# Altium schematic sheet IoTsmart RP2350A 2-02.SchDoc("IoTsmart RP2350A 2-02")
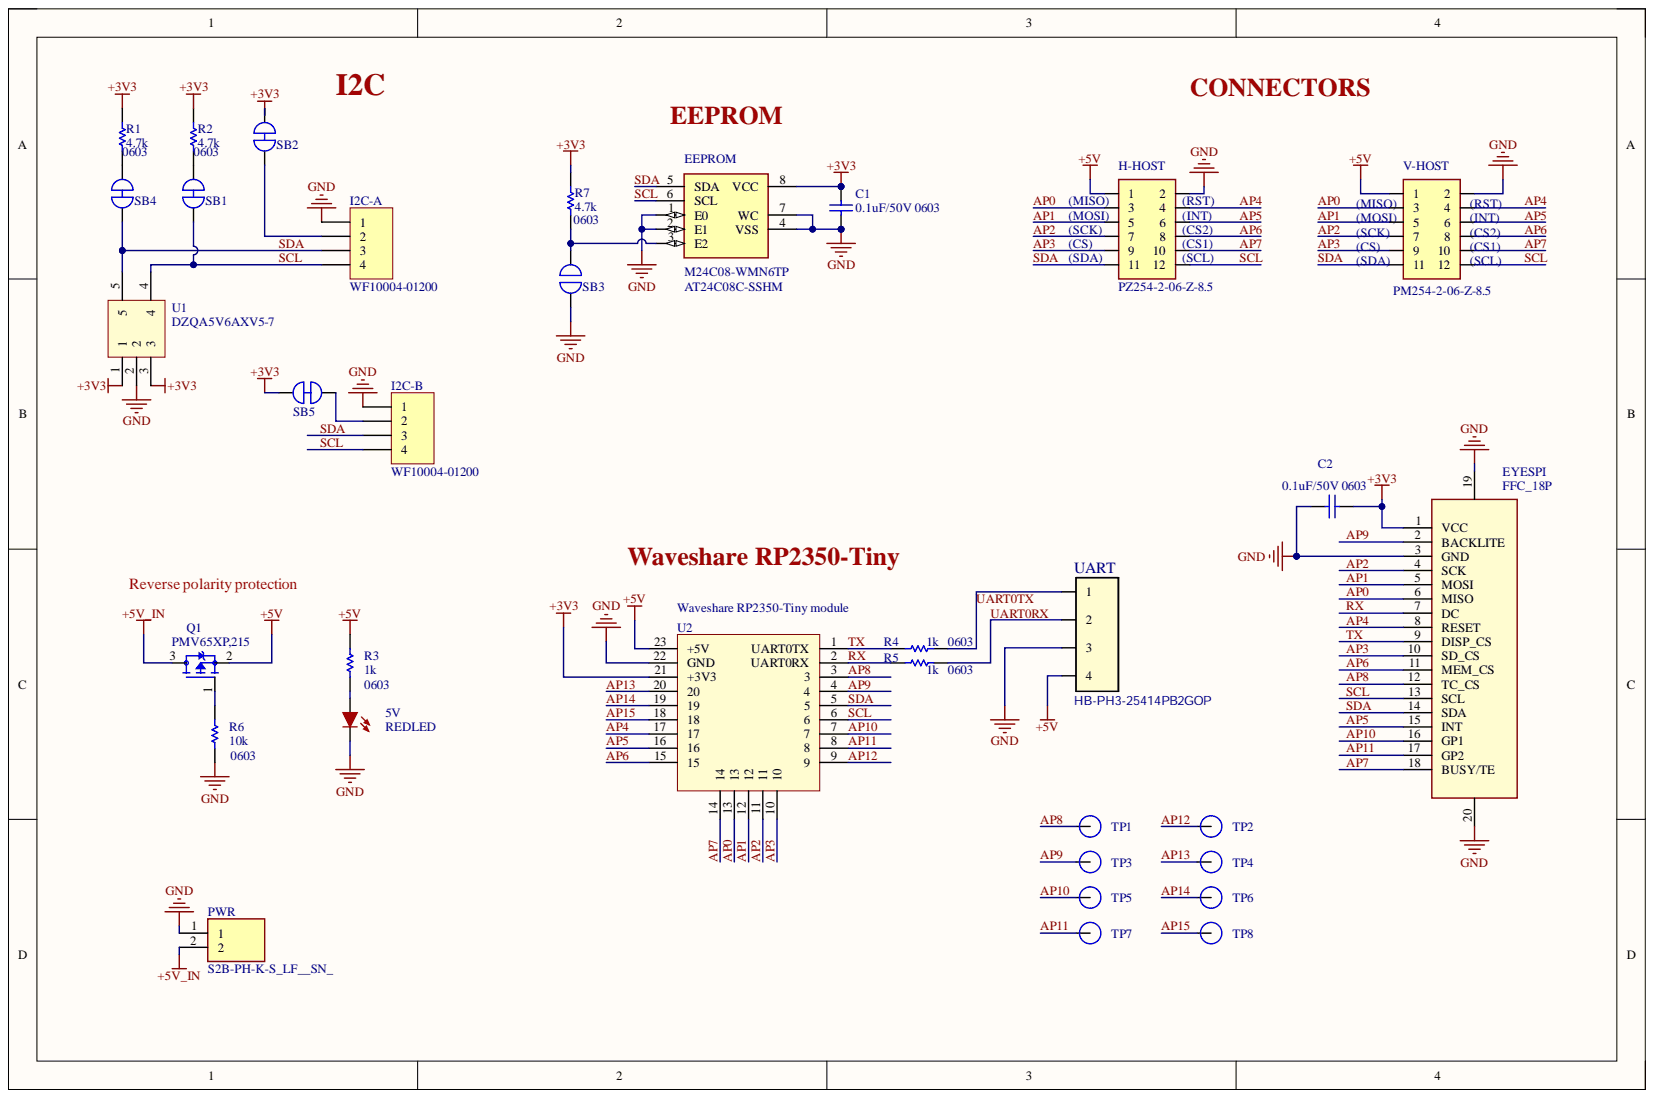
[diagram p0: IoTsmart RP2350A 2-02.SchDoc]

## Parts
5V  REDLED  Case Code (Imperial): 0603  Reverse Voltage: 5V  [LED0603_RED]
C1  0.1uF/50V 0603  [CAPC1608X100N]
C2  0.1uF/50V 0603  [CAPC1608X100N]
EEPROM  M24C08-WMN6TP  [SOIC-8]
EYESPI  FFC_18P  [FFC_18P]
H-HOST  PZ254-2-06-Z-8.5  [PBD-12R]
I2C-A  WF10004-01200  [SM04B-SRSS-TB(LF)(SN)]
I2C-B  WF10004-01200  [SM04B-SRSS-TB(LF)(SN)]
PWR  S2B-PH-K-S_LF__SN_  [SHDRRA2W50P0X200_1X2_590X760X480P]
Q1  PMV65XP,215  [SOT95P230X110-3N]
R1  4.7k  [RESC1608X50N]
R2  4.7k  [RESC1608X50N]
R3  1k  [RESC1608X50N]
R4  1k  [RESC1608X50N]
R5  1k  [RESC1608X50N]
R6  10k  [RESC1608X50N]
R7  4.7k  [RESC1608X50N]
SB1  SOLDER_JUMPER_NC  [SOLDER_JUMPER_NC_2]  (value not drawn)  (no BOM)
SB2  SOLDER_JUMPER_NC  [SOLDER_JUMPER_NC_2]  (value not drawn)  (no BOM)
SB3  SOLDER_JUMPER_NC  [SOLDER_JUMPER_NC_2-open]  (value not drawn)  (no BOM)
SB4  SOLDER_JUMPER_NC  [SOLDER_JUMPER_NC_2]  (value not drawn)  (no BOM)
SB5  SOLDER_JUMPER_NC  [SOLDER_JUMPER_NC_2]  (value not drawn)  (no BOM)
TP1  TP  [TEST_PAD]  (no BOM)
TP2  TP  [TEST_PAD]  (no BOM)
TP3  TP  [TEST_PAD]  (no BOM)
TP4  TP  [TEST_PAD]  (no BOM)
TP5  TP  [TEST_PAD]  (no BOM)
TP6  TP  [TEST_PAD]  (no BOM)
TP7  TP  [TEST_PAD]  (no BOM)
TP8  TP  [TEST_PAD]  (no BOM)
U1  DZQA5V6AXV5-7  [SOT50P160X60-5N]
U2  Waveshare RP2350-Tiny module  [ESP32S3Tiny]
UART  HB-PH3-25414PB2GOP  [XS PLS-4]
V-HOST  PM254-2-06-Z-8.5  [PBD-12]

## Nets
+3V3: C1.2 C2.1 EEPROM.8 EYESPI.1 R1.2 R2.2 R7.2 SB2.2 SB5.2 U1.1 U1.3 U2.21
+5V: H-HOST.1 Q1.2 R3.2 U2.23 UART.4 V-HOST.1
+5V_IN: PWR.2 Q1.3
GND: 5V.K C1.1 C2.2 EEPROM.1 EEPROM.2 EEPROM.4 EEPROM.7 EYESPI.3 EYESPI.19 EYESPI.20 H-HOST.2 I2C-A.1 I2C-B.1 PWR.1 R6.1 SB3.1 U1.2 U2.22 UART.3 V-HOST.2
AP0: EYESPI.6 H-HOST.3 U2.13 V-HOST.3
AP1: EYESPI.5 H-HOST.5 U2.12 V-HOST.5
AP2: EYESPI.4 H-HOST.7 U2.11 V-HOST.7
AP3: EYESPI.10 H-HOST.9 U2.10 V-HOST.9
AP4: EYESPI.8 H-HOST.4 U2.17 V-HOST.4
AP5: EYESPI.15 H-HOST.6 U2.16 V-HOST.6
AP6: EYESPI.11 H-HOST.8 U2.15 V-HOST.8
AP7: EYESPI.18 H-HOST.10 U2.14 V-HOST.10
AP8: EYESPI.12 TP1.1 U2.3
AP9: EYESPI.2 TP3.1 U2.4
AP10: EYESPI.16 TP5.1 U2.7
AP11: EYESPI.17 TP7.1 U2.8
AP12: TP2.1 U2.9
AP13: TP4.1 U2.20
AP14: TP6.1 U2.19
AP15: TP8.1 U2.18
RX: EYESPI.7 R5.1 U2.2
SCL: EEPROM.6 EYESPI.13 H-HOST.12 I2C-A.4 I2C-B.4 SB1.1 U1.4 U2.6 V-HOST.12
SDA: EEPROM.5 EYESPI.14 H-HOST.11 I2C-A.3 I2C-B.3 SB4.1 U1.5 U2.5 V-HOST.11
TX: EYESPI.9 R4.1 U2.1
UART0RX: R5.2 UART.2
UART0TX: R4.2 UART.1
Net5V_A: 5V.A R3.1
NetEEPROM_3: EEPROM.3 R7.1 SB3.2
NetI2C-A_2: I2C-A.2 SB2.1
NetI2C-B_2: I2C-B.2 SB5.1
NetQ1_1: Q1.1 R6.2
NetR1_1: R1.1 SB4.2
NetR2_1: R2.1 SB1.2

## Library data of the parts
5V = LED0603_REDLED: -40℃~+85℃ Red 0603 LED Indication - Discrete ROHS; Manufacturer: Hubei KENTO Elec; Manufacturer Part Number: KT-0603R; Dominant Wavelength: 605nm; Forward Current: 30mA; Forward Voltage: 2V; Illumination Color: Orange; Lens Color: Colorless; Lens Style: Diffused,Colorless,Rectangular; Lens Transparency: Clear; Luminous Intensity: 90mcd; Number of Elements: 1; Power Dissipation: 75mW; Test Current: 20mA; Viewing Angle: 130°; Wavelength: 611nm; Case Code (Metric): 1608; Case/Package: 0603; Category: LEDs; Color: Red; Component Kind: Standard; ComponentLink1Description: https://datasheet.ciiva.com/2109/ltst-c191kfkt-2109968.pdf?src-supplier=Digi-Key; ComponentLink1URL: https://datasheet.ciiva.com/2109/ltst-c191kfkt-2109968.pdf?src-supplier=Digi-Key; ComponentLink2Description: https://datasheet.ciiva.com/11775/0900766b80dc02b0-11775613.pdf?src-supplier=RSComponents; ComponentLink2URL: https://datasheet.ciiva.com/11775/0900766b80dc02b0-11775613.pdf?src-supplier=RSComponents; ComponentLink3Description: https://datasheet.ciiva.com/12400/100-ltst-c191kfkt-39904-12400811.pdf?src-supplier=Mouser; ComponentLink3URL: https://datasheet.ciiva.com/12400/100-ltst-c191kfkt-39904-12400811.pdf?src-supplier=Mouser; ComponentLink4Description: https://datasheet.ciiva.com/15201/getdatasheetpartid-631075-15201391.pdf?src-supplier=Verical; ComponentLink4URL: https://datasheet.ciiva.com/15201/getdatasheetpartid-631075-15201391.pdf?src-supplier=Verical; ComponentLink5Description: https://datasheet.ciiva.com/2109/s-110-ltst-c191kfkt-2109969.pdf?src-supplier=Digi-Key; ComponentLink5URL: https://datasheet.ciiva.com/2109/s-110-ltst-c191kfkt-2109969.pdf?src-supplier=Digi-Key; Depth: 0.8mm; Height: 0.55mm; Lead Free: Lead Free; Length: 1.6mm; Max Operating Temperature: 85°C; Min Operating Temperature: -55°C; Mount: Surface Mount; Number of LEDs: 1; Number of Pins: 2; Package Quantity: 1; Packaging: Tape and Reel; Power Rating: 75mW; Pricing 1: 1=0.24000, 10=0.13200, 100=0.06110, 500=0.05640, 1000=0.04418, 2000=0.04324 (USD); Radiation Hardening: No; RoHS: Yes; RoHS Compliant: Yes; Stock 1: 308946; Supplier 1: Digi-Key; Supplier Part Number 1: 160-1445-1-ND; Width: 0.8mm; Library Name: IoTextra_Input_2-03.SCHLIB
C1 C2 = Cap: 50V 100nF X7R ±10% 0603 Multilayer Ceramic Capacitors MLCC - SMD/SMT ROHS; Manufacturer: Walsin Tech Corp; Manufacturer Part Number: 0603B104K500CT; Component Kind: Standard; Library Name: Miscellaneous Devices.IntLib; Signal Integrity: Cap; Simulation: CAP; Supplier 1: LCSC; Supplier Part Number 1: C314282; Value: 0.1uF/50V 0603
EEPROM = M24C08-WMN: Component Kind: Standard; Description: M24C08-WMN6TP; Library Name: IoTextra_Input_2-03.SCHLIB
EYESPI = 0.5K-HX-18PWB: SMD,P=0.5mm,Surface Mount，Right Angle; Component Kind: Standard; LCSC Part #: C2919497; Library Name: IoTsmart XIAO.SCHLIB
H-HOST V-HOST = PBD-12R: 2.54mm, PBD type 2x06 Pin, female; system: connector; Component Kind: Standard; Library Name: IoTextra_Input_2-03.SCHLIB
  H-HOST: Value: PZ254-2-06-Z-8.5
  V-HOST: Supplier 1: LCSC; Supplier Part Number 1: C2897407; Value: PM254-2-06-Z-8.5
I2C-A I2C-B = Header 4: Header, 4-Pin; Component Kind: Standard; LatestRevisionDate: 17-Jul-2002; LatestRevisionNote: Re-released for DXP Platform.; Signal Integrity: Connector; Library Name: IoTextra_Input_2-03.SCHLIB; Publisher: Altium Limited
PWR = S2B-PH-K-S_LF__SN_: PH-2.0mm Header side entry 2 way JST PH Series, Series Number S2B, 2mm Pitch 2 Way 1 Row Right Angle PCB Header, Through Hole Termination, 2A; Manufacturer_Name: JST (JAPAN SOLDERLESS TERMINALS); Manufacturer_Part_Number: S2B-PH-K-S(LF)(SN); Geometry.Height: 4.8mm; Arrow Part Number: S2B-PH-K-S(LF)(SN); Arrow Price/Stock: https://www.arrow.com/en/products/s2b-ph-k-s-lf-sn/jst-manufacturing?region=europe; Component Kind: Standard; Datasheet Link: http://uk.rs-online.com/web/p/products/8201494; Library Name: IoTextra_Input_2-03.SCHLIB
Q1 = PMV65XP,215: MOSFET P-CH 20V 2.8A TO236AB; Manufacturer: Nexperia USA; Component Kind: Standard; Library Name: Symbol.SchLib; Manufacturer Part Number: PMV65XP,215; Supplier 1: LCSC; Supplier Part Number 1: C75561
R1 R2 R4 R5 R7 = Res1_1: Resistor; Component Kind: Standard; LatestRevisionDate: 17-Jul-2002; LatestRevisionNote: Re-released for DXP Platform.; PackageDescription: Axial Device, Thru-Hole; 2 Leads; 0.3 in Pin Spacing; PackageReference: AXIAL-0.3; Published: 8-Jun-2000; Signal Integrity: Res; Simulation: RESISTOR; Library Name: IoTextra_Input_2-03.SCHLIB; Publisher: Altium Limited
  R1 R2 R7: Value: 4.7k
  R4 R5: Value: 1k
R3 R6 = Res1: Resistor; Component Kind: Standard; LatestRevisionDate: 17-Jul-2002; LatestRevisionNote: Re-released for DXP Platform.; Library Name: Miscellaneous Devices.IntLib; PackageDescription: Axial Device, Thru-Hole; 2 Leads; 0.3 in Pin Spacing; PackageReference: AXIAL-0.3; Published: 8-Jun-2000; Signal Integrity: Res; Simulation: RESISTOR; Publisher: Altium Limited
  R3: Value: 1k
  R6: Value: 10k
SB1 SB2 SB4 SB5 = SOLDER_JUMPER_NC: Solder Jumper; Library Name: IoTextra_Input_2-03.SCHLIB
SB3 = SOLDER_JUMPER_NC_1: Solder Jumper; Library Name: IoTsmart ESP32-S3.SCHLIB
TP1-TP8 = TEST_PAD: TEST PAD; Library Name: IoTsmart ESP32-S3_2-01.SCHLIB
U1 = NZQA5V6XV5T1G: TVS DIODE 3VWM 10.5VC SOT553; Component Kind: Standard; Library Name: IoTextra_Input_2-03.SCHLIB
U2 = Waveshare ESP32-S3-Tiny: Component Kind: Standard; Library Name: IoTsmart ESP32-S3.SCHLIB
UART = XS PLS-4: PLS-4 (PLS-40 1/10); BaseParams: I=1А; U=250В; 1x4 pin; 2.54mm; CompGroup: Разъёмы; CompType: Разъём печатной платы; Man: CONNFLY Electronic Corp. ,Ltd; ManCode: CONNFLY; Supp1Price100: 0,4988; Supp1Price1000: 0,0499; TempRange: -55°C - +140°C; Component Kind: Standard; ManLink: http://www.connfly.com/productshow.aspx?id=363; Pack: PLS-4; PartNumber: PLS-4; Supp1Link: http://www.compel.ru/infosheet/CONNFLY/PLS-40/; Value: PLS-4; Library Name: IoTextra_Input_2-03.SCHLIB
